# Altium schematic sheet Power.SchDoc(Power)
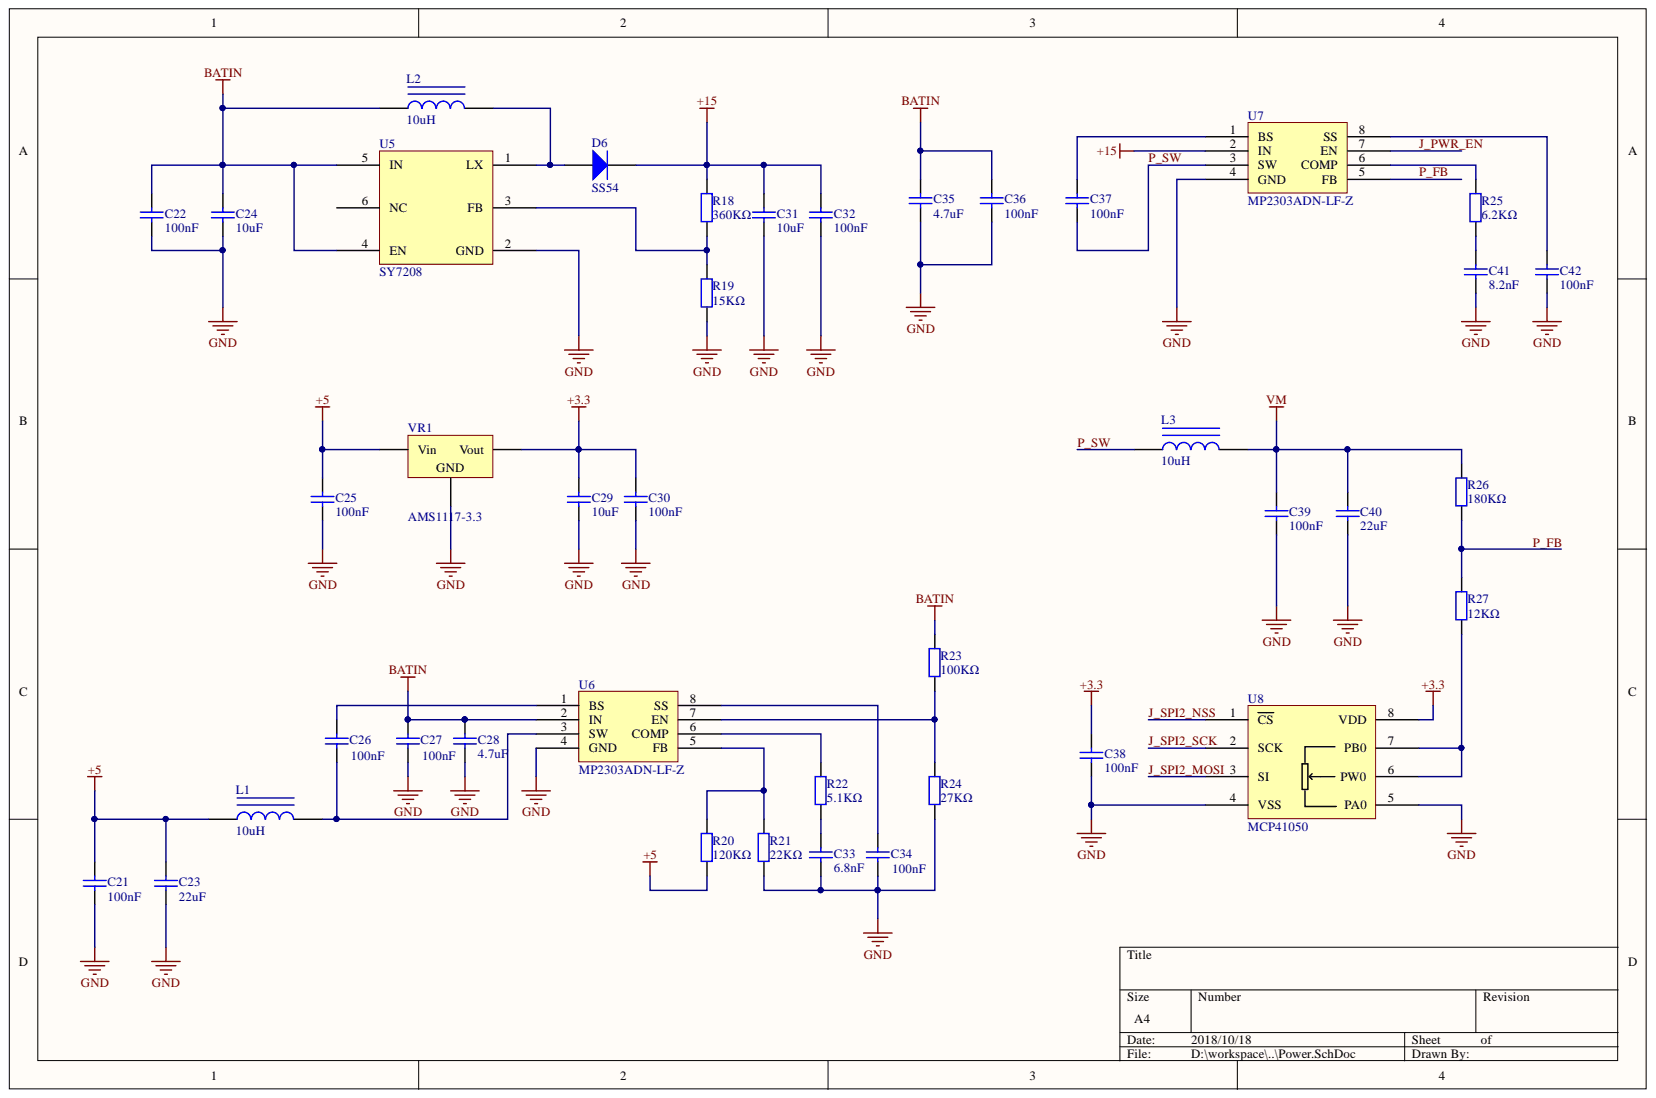
[diagram p0: Power.SchDoc]

## Parts
C21  100nF  [0603_C]
C22  100nF  [0603_C]
C23  22uF  [0805_C]
C24  10uF  [1206_C]
C25  100nF  [0603_C]
C26  100nF  [0603_C]
C27  100nF  [0603_C]
C28  4.7uF  [0805_C]
C29  10uF  [1206_C]
C30  100nF  [0603_C]
C31  10uF  [1206_C]
C32  100nF  [0603_C]
C33  6.8nF  [0603_C]
C34  100nF  [0603_C]
C35  4.7uF  [0805_C]
C36  100nF  [0603_C]
C37  100nF  [0603_C]
C38  100nF  [0603_C]
C39  100nF  [0603_C]
C40  22uF  [0805_C]
C41  8.2nF  [0603_C]
C42  100nF  [0603_C]
D6  SS54  [SMA(DO-214AC)_S1]
L1  10uH  [A7503AY]
L2  10uH  [A7503AY]
L3  10uH  [A7503AY]
R18  360KΩ  [0603_R]
R19  15KΩ  [0603_R]
R20  120KΩ  [0603_R]
R21  22KΩ  [0603_R]
R22  5.1KΩ  [0603_R]
R23  100KΩ  [0603_R]
R24  27KΩ  [0603_R]
R25  6.2KΩ  [0603_R]
R26  180KΩ  [0603_R]
R27  12KΩ  [0603_R]
U5  SY7208  [SOT-23-6]
U6  MP2303ADN-LF-Z  [SOIC-8_EP_150mil]
U7  MP2303ADN-LF-Z  [SOIC-8_EP_150mil]
U8  MCP41050  [SOIC-8_150mil]
VR1  AMS1117-3.3  [SOT-223]

## Nets
+3.3: C29.2 C30.2 C38.2 U8.8(VDD) VR1.2
+5: C21.2 C23.2 C25.2 L1.1 R20.1 VR1.3
+15: C31.2 C32.2 D6.2 R18.2 U7.2(IN)
BATIN: C22.2 C24.2 C27.2 C28.2 C35.2 C36.2 L2.1 R23.1 U5.4(EN) U5.5(IN) U6.2(IN)
GND: C21.1 C22.1 C23.1 C24.1 C25.1 C27.1 C28.1 C29.1 C30.1 C31.1 C32.1 C33.1 C34.1 C35.1 C36.1 C38.1 C39.1 C40.1 C41.1 C42.1 R19.1 R21.1 R24.1 U5.2(GND) U6.4(GND) U7.4(GND) U8.4(VSS) U8.5(PA0) VR1.1
VM: C39.2 C40.2 L3.2 R26.2
J_PWR_EN: U7.7(EN)
J_SPI2_MOSI: U8.3(SI)
J_SPI2_NSS: U8.1(CS)
J_SPI2_SCK: U8.2(SCK)
P_FB: R26.1 R27.2 U7.5(FB)
P_SW: C37.1 L3.1 U7.3(SW)
NetC26_1: C26.1 L1.2 U6.3(SW)
NetC26_2: C26.2 U6.1(BS)
NetC33_2: C33.2 R22.1
NetC34_2: C34.2 U6.8(SS)
NetC37_2: C37.2 U7.1(BS)
NetC41_2: C41.2 R25.1
NetC42_2: C42.2 U7.8(SS)
NetD6_1: D6.1 L2.2 U5.1(LX)
NetR18_1: R18.1 R19.2 U5.3(FB)
NetR20_2: R20.2 R21.2 U6.5(FB)
NetR22_2: R22.2 U6.6(COMP)
NetR23_2: R23.2 R24.2 U6.7(EN)
NetR25_2: R25.2 U7.6(COMP)
NetR27_1: R27.1 U8.6(PW0) U8.7(PB0)

Not connected: U5 6(NC)

## Library data of the parts
C21 C22 C25-C27 C30 C32 C34 C36-C39 C42 = 0603 100nF (104) 10% 50V: 贴片电容; SuppliersPartNumber: C14663; Supplier: LC; Package: 0603; Library Name: Cap.SchLib; ComponentLink1URL: http://www.szlcsc.com/product/details_15331.html; ComponentLink1Description: 供应商链接; Component Kind: Standard
C23 C40 = 0805 22uF (226) 20% 25V: 贴片电容; SuppliersPartNumber: C45783; Supplier: LC; Package: 0805; Library Name: Cap.SchLib; ComponentLink1URL: http://www.szlcsc.com/product/details_46786.html; ComponentLink1Description: 供应商链接; Component Kind: Standard
C24 C29 C31 = 1206 10uF (106) 10% 50V: 贴片电容; SuppliersPartNumber: C13585; Supplier: LC; Package: 1206; Library Name: Cap.SchLib; ComponentLink1URL: http://www.szlcsc.com/product/details_14236.html; ComponentLink1Description: 供应商链接; Component Kind: Standard
C28 C35 = 0805 4.7uF (475) 10% 25V: 贴片电容; SuppliersPartNumber: C1779; Supplier: LC; Package: 0805; Library Name: Cap.SchLib; ComponentLink1URL: http://www.szlcsc.com/product/details_2131.html; ComponentLink1Description: 供应商链接; Component Kind: Standard
C33 = 0603 6.8nF (682) 10% 50V: 贴片电容; SuppliersPartNumber: C1631; Supplier: LC; Package: 0603; Library Name: Cap.SchLib; ComponentLink1URL: http://www.szlcsc.com/product/details_1983.html; ComponentLink1Description: 供应商链接; Component Kind: Standard
C41 = 0603 8.2nF (822) 10% 50V: 贴片电容; SuppliersPartNumber: C27920; Supplier: LC; Package: 0603; Library Name: Cap.SchLib; ComponentLink1URL: http://www.szlcsc.com/product/details_28670.html; ComponentLink1Description: 供应商链接; Component Kind: Standard
D6 = SMA(DO-214AC) SS54: 二极管; SuppliersPartNumber: C22452; Supplier: LC; Package: SMA(DO-214AC); Library Name: Diode.SchLib; ComponentLink1URL: http://www.szlcsc.com/product/details_23178.html; ComponentLink1Description: 供应商链接; Component Kind: Standard
L1-L3 = A7503AY: Library Name: SmartCar.SchLib; Component Kind: Standard
R18 = 0603 360KΩ (3603) 1%: 贴片电阻; SuppliersPartNumber: C23146; Supplier: LC; Package: 0603; Library Name: RES.SchLib; ComponentLink1URL: http://www.szlcsc.com/product/details_23873.html; ComponentLink1Description: 供应商链接; Component Kind: Standard
R19 = 0603 15KΩ (1502) 1%: 贴片电阻; SuppliersPartNumber: C22809; Supplier: LC; Package: 0603; Library Name: RES.SchLib; ComponentLink1URL: http://www.szlcsc.com/product/details_23536.html; ComponentLink1Description: 供应商链接; Component Kind: Standard
R20 = 0603 120KΩ (1203) 1%: 贴片电阻; SuppliersPartNumber: C25808; Supplier: LC; Package: 0603; Library Name: RES.SchLib; ComponentLink1URL: http://www.szlcsc.com/product/details_26551.html; ComponentLink1Description: 供应商链接; Component Kind: Standard
R21 = 0603 22KΩ (2202) 1%: 贴片电阻; SuppliersPartNumber: C31850; Supplier: LC; Package: 0603; Library Name: RES.SchLib; ComponentLink1URL: http://www.szlcsc.com/product/details_32812.html; ComponentLink1Description: 供应商链接; Component Kind: Standard
R22 = 0603 5.1KΩ (5101) 1%: 贴片电阻; SuppliersPartNumber: C23186; Supplier: LC; Package: 0603; Library Name: RES.SchLib; ComponentLink1URL: http://www.szlcsc.com/product/details_23913.html; ComponentLink1Description: 供应商链接; Component Kind: Standard
R23 = 0603 100KΩ (1003) 1%: 贴片电阻; SuppliersPartNumber: C25803; Supplier: LC; Package: 0603; Library Name: RES.SchLib; ComponentLink1URL: http://www.szlcsc.com/product/details_26546.html; ComponentLink1Description: 供应商链接; Component Kind: Standard
R24 = 0603 27KΩ (2702) 1%: 贴片电阻; SuppliersPartNumber: C22967; Supplier: LC; Package: 0603; Library Name: RES.SchLib; ComponentLink1URL: http://www.szlcsc.com/product/details_23694.html; ComponentLink1Description: 供应商链接; Component Kind: Standard
R25 = 0603 6.2KΩ (6201) 1%: 贴片电阻; SuppliersPartNumber: C4260; Supplier: LC; Package: 0603; Library Name: RES.SchLib; ComponentLink1URL: http://www.szlcsc.com/product/details_4667.html; ComponentLink1Description: 供应商链接; Component Kind: Standard
R26 = 0603 180KΩ (1803) 1%: 贴片电阻; SuppliersPartNumber: C22827; Supplier: LC; Package: 0603; Library Name: RES.SchLib; ComponentLink1URL: http://www.szlcsc.com/product/details_23554.html; ComponentLink1Description: 供应商链接; Component Kind: Standard
R27 = 0603 12KΩ (1202) 1%: 贴片电阻; SuppliersPartNumber: C22790; Supplier: LC; Package: 0603; Library Name: RES.SchLib; ComponentLink1URL: http://www.szlcsc.com/product/details_23517.html; ComponentLink1Description: 供应商链接; Component Kind: Standard
U5 = SY7208: High Efficiency 1MHz, 2A Step Up Regulator; Library Name: SmartCar.SchLib; Component Kind: Standard
U6 U7 = MP2303ADN-LF-Z_[C18876]: DC-DC芯片; SuppliersPartNumber: C18876; Supplier: LC; Package: SOIC-8_EP_150mil; Library Name: IC.SchLib; ComponentLink1URL: http://www.szlcsc.com/product/details_19579.html; ComponentLink1Description: 供应商链接; Component Kind: Standard
VR1 = SOT-223 AMS1117-3.3: 低压差线性稳压(LDO); SuppliersPartNumber: C6186; Supplier: LC; Package: SOT-223; Library Name: LDO.SchLib; ComponentLink1URL: http://www.szlcsc.com/product/details_6652.html; ComponentLink1Description: 供应商链接; Component Kind: Standard
U8 = MCP41050: Library Name: SmartCar.SchLib; Component Kind: Standard
